# Altium schematic sheet Power.SchDoc
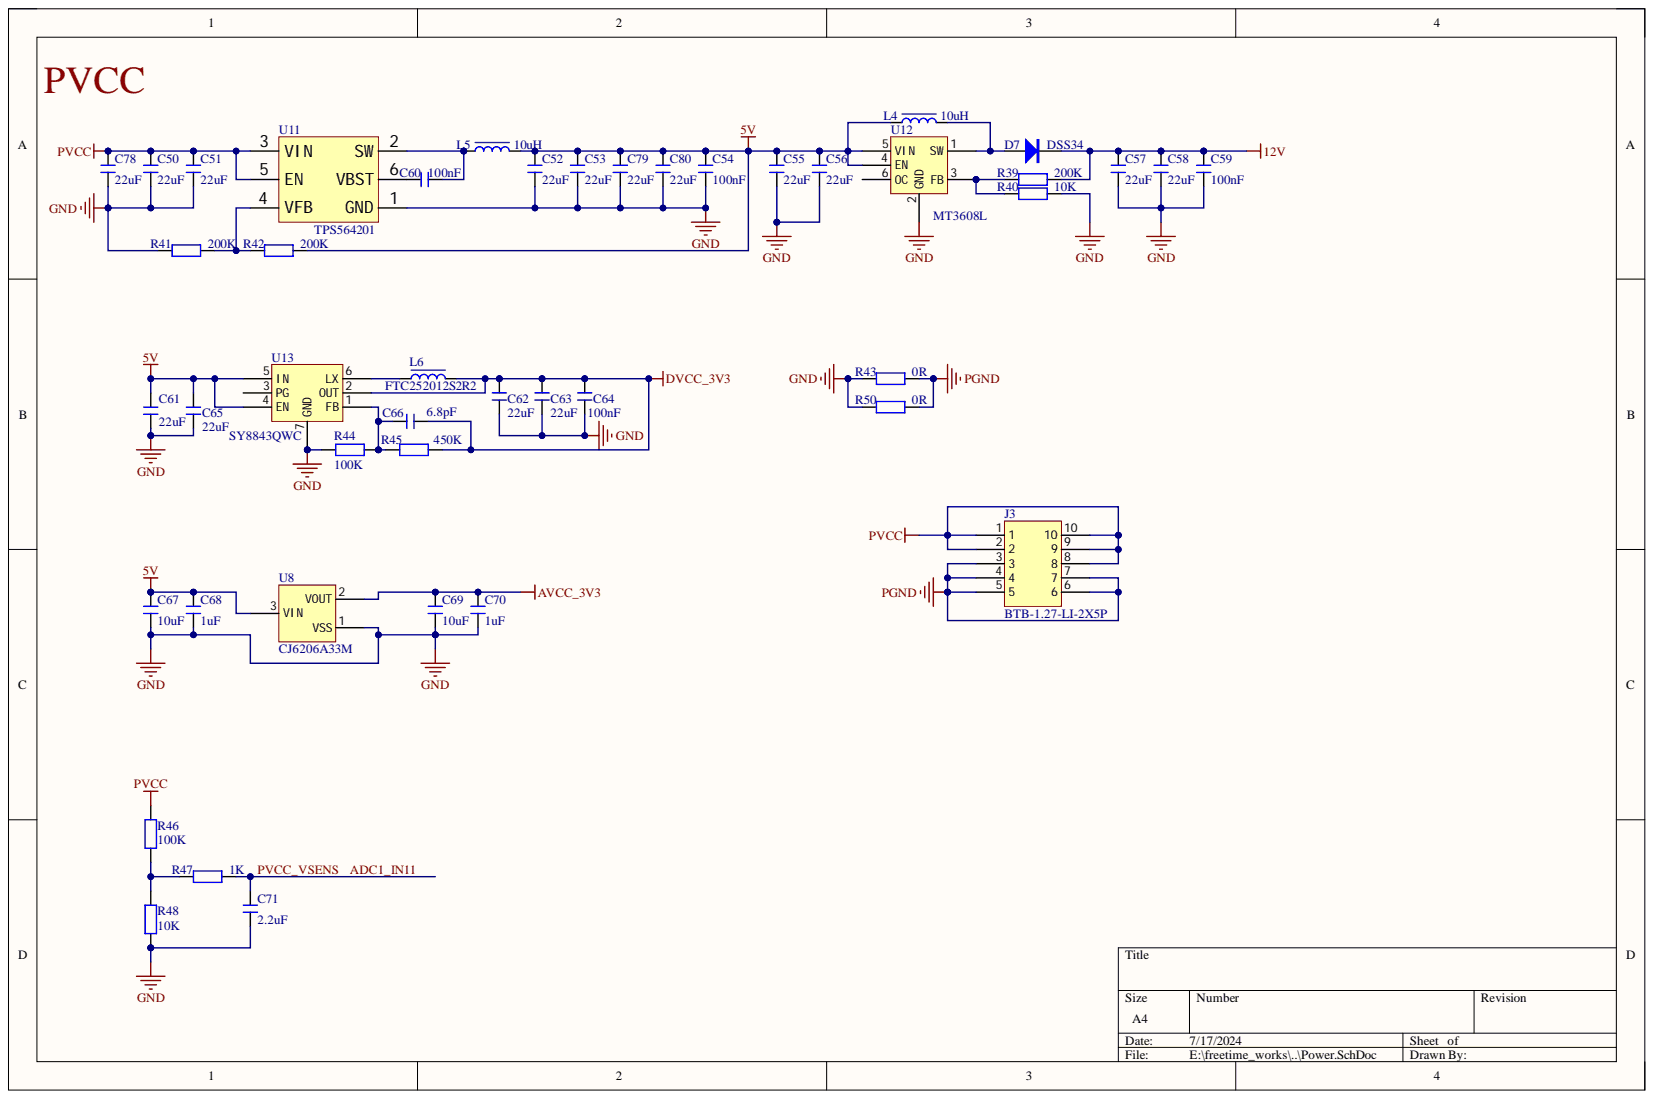
[diagram p0: Power.SchDoc]

## Parts
C50  22uF  [C 1206_L]
C51  22uF  [C 1206_L]
C52  22uF  [C 0603_L]
C53  22uF  [C 0603_L]
C54  100nF  [C 0402_L]
C55  22uF  [C 0603_L]
C56  22uF  [C 0603_L]
C57  22uF  [C 0603_L]
C58  22uF  [C 0603_L]
C59  100nF  [C 0402_L]
C60  100nF  [C 0402_L]
C61  22uF  [C 0603_L]
C62  22uF  [C 0603_L]
C63  22uF  [C 0603_L]
C64  100nF  [C 0402_L]
C65  22uF  [C 0603_L]
C66  6.8pF  [C 0402_L]
C67  10uF  [C 0402_L]
C68  1uF  [C 0402_L]
C69  10uF  [C 0402_L]
C70  1uF  [C 0402_L]
C71  2.2uF  [C 0402_L]
C78  22uF  [C 1206_L]
C79  22uF  [C 0603_L]
C80  22uF  [C 0603_L]
D7  DSS34  [SOD-123FL]
J3  BTB-1.27-LI-2X5P  [1.27-LI-2X5P]
L4  10uH  [L-4020]
L5  10uH  [L-0630]
L6  FTC252012S2R2  [252012]
R39  200K  [R 0402_L]
R40  10K  [R 0402_L]
R41  200K  [R 0402_L]
R42  200K  [R 0402_L]
R43  0R  [R 1206_L]
R44  100K  [R 0402_L]
R45  450K  [R 0402_L]
R46  100K  [R 0402_L]
R47  1K  [R 0402_L]
R48  10K  [R 0402_L]
R50  0R  [R 1206_L]
U8  CJ6206A33M  [SOT23-3L]
U11  TPS564201  [SOT23-6L]
U12  MT3608L  [SOT23-6L]
U13  SY8843QWC  [QFN7 1.5X1.5]

## Nets
5V: C52.2 C53.2 C54.2 C55.2 C56.2 C61.2 C65.2 C67.2 C68.2 C79.2 C80.2 L4.1 L5.2 R42.2 U8.3 U12.4(EN) U12.5(VIN) U13.4(EN) U13.5(IN)
12V: C57.2 C58.2 C59.2 D7.1 R39.2
AVCC_3V3: C69.2 C70.2 U8.2
DVCC_3V3: C62.2 C63.2 C64.2 C66.1 L6.2 R45.1 U13.2(OUT)
GND: C50.1 C51.1 C52.1 C53.1 C54.1 C55.1 C56.1 C57.1 C58.1 C59.1 C61.1 C62.1 C63.1 C64.1 C65.1 C67.1 C68.1 C69.1 C70.1 C71.1 C78.1 C79.1 C80.1 R40.2 R41.1 R43.1 R44.2 R48.1 R50.1 U8.1 U11.1(GND) U12.2(GND) U13.7(GND)
PGND: J3.3 J3.4 J3.5 J3.6 J3.7 R43.2 R50.2
PVCC: C50.2 C51.2 C78.2 J3.1 J3.2 J3.8 J3.9 J3.10 R46.2 U11.3(VIN) U11.5(EN)
ADC1_IN11: C71.2 R47.1
NetC60_1: C60.1 U11.6(VBST)
NetC60_2: C60.2 L5.1 U11.2(SW)
NetC66_2: C66.2 R44.1 R45.2 U13.1(FB)
NetD7_2: D7.2 L4.2 U12.1(SW)
NetL6_1: L6.1 U13.6(LX)
NetR39_1: R39.1 R40.1 U12.3(FB)
NetR41_2: R41.2 R42.1 U11.4(VFB)
NetR46_1: R46.1 R47.2 R48.2

Not connected: U12 6(OC); U13 3(PG)

## Library data of the parts
C50-C71 C78-C80 = C: 无极性贴片电容; 版权归属: 源创客; BOM名称: 无极性贴片电容; Component Kind: Standard; Library Name: 3.1   - 电阻-电容-电感.SchLib; 学习资源: www.ooocke.com
D7 = DSS34: 肖特基二极管; Component Kind: Standard; Library Name: 8.1   - 二极管-整流桥.SchLib
J3 = LI-2X5P: Component Kind: Standard; Library Name: 4.16 - BTB连接器 - 1.27.SchLib
L4-L6 = L-CD: 贴片功率电感; BOM名称: 功率电感; 版权归属: 源创客; Component Kind: Standard; Library Name: 3.1   - 电阻-电容-电感.SchLib; 学习资源: www.ooocke.com
R39-R48 R50 = R: 贴片电阻; 版权归属: 源创客; BOM名称: 贴片电阻; Component Kind: Standard; Library Name: 3.1   - 电阻-电容-电感.SchLib; 学习资源: www.ooocke.com
U8 = LN6206PXXX: 三端稳压芯片  6V 250mA; Component Kind: Standard; Library Name: 1.3   - 电源类芯片.SchLib
U11 = TPS563200: 3A  4.5V-17V输入 同步; Component Kind: Standard; Library Name: 1.3   - 电源类芯片.SchLib
U12 = MT3608L: 2.5A 1.5MHZ  宽输入升压; Component Kind: Standard; Library Name: 1.3   - 电源类芯片.SchLib
U13 = SY8843QWC: DC-DC 1.5M  VIN:2.7~5.5V 3A; Component Kind: Standard; Library Name: 1.3   - 电源类芯片.SchLib
